# Altium schematic sheet Prosjektoppgave.SchDoc
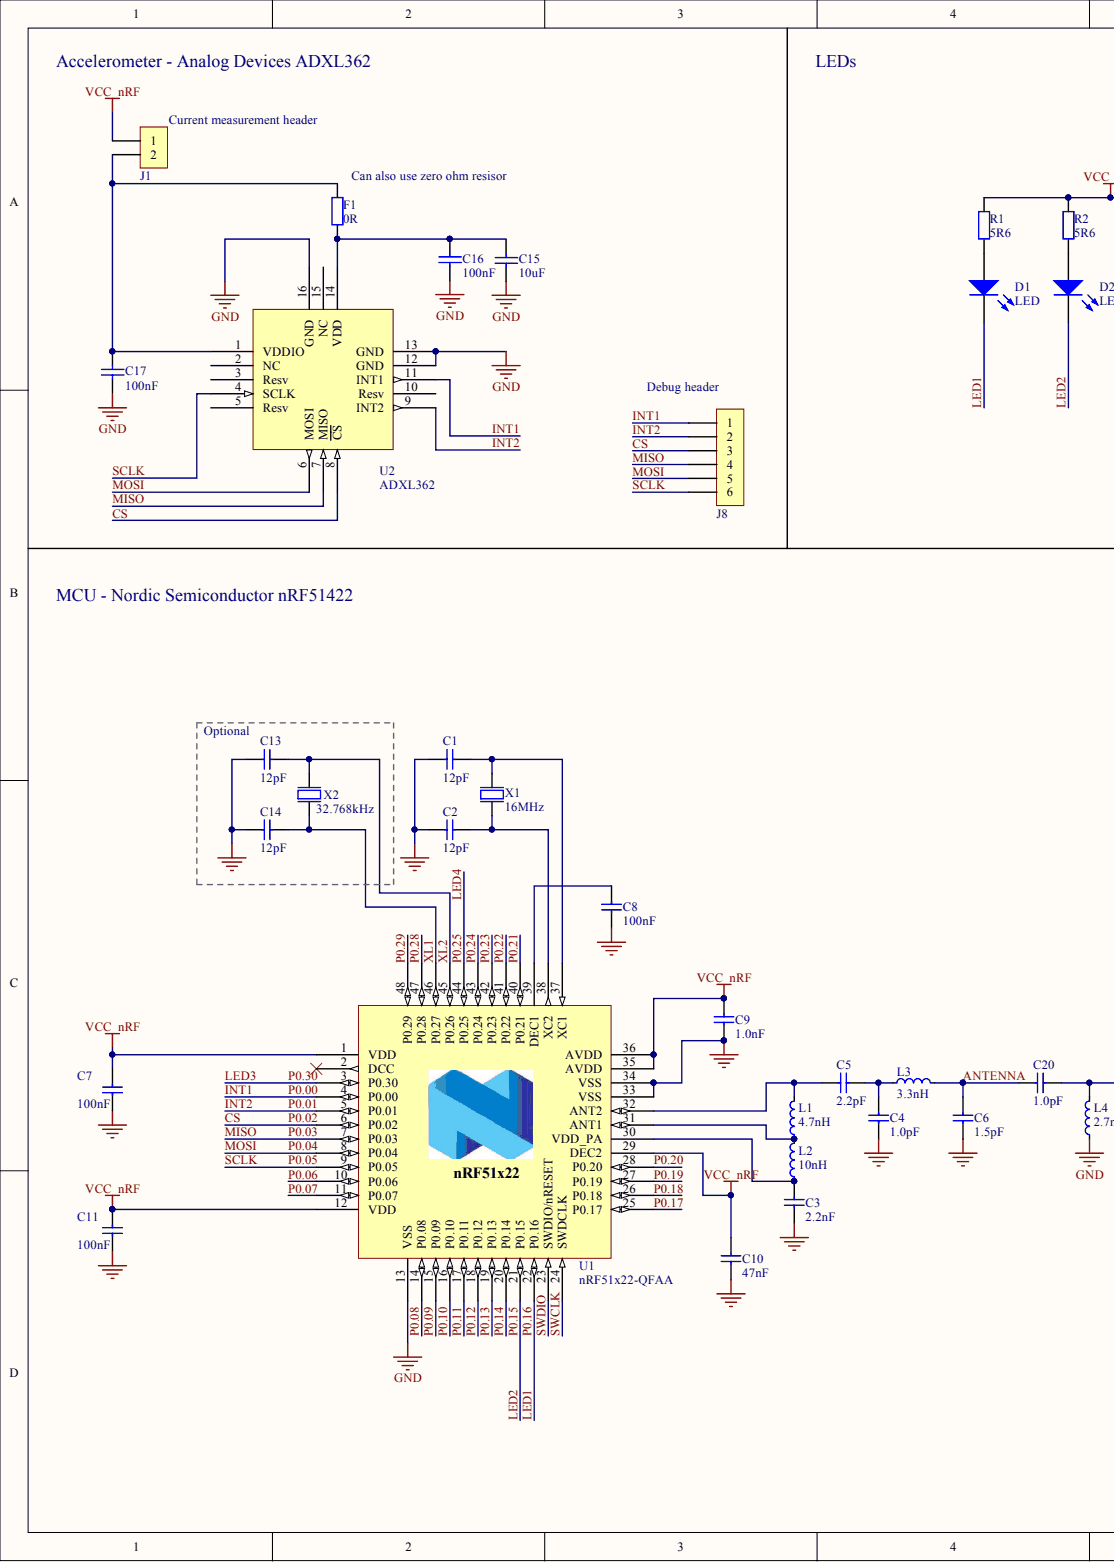
[diagram p0: Prosjektoppgave.SchDoc, left]
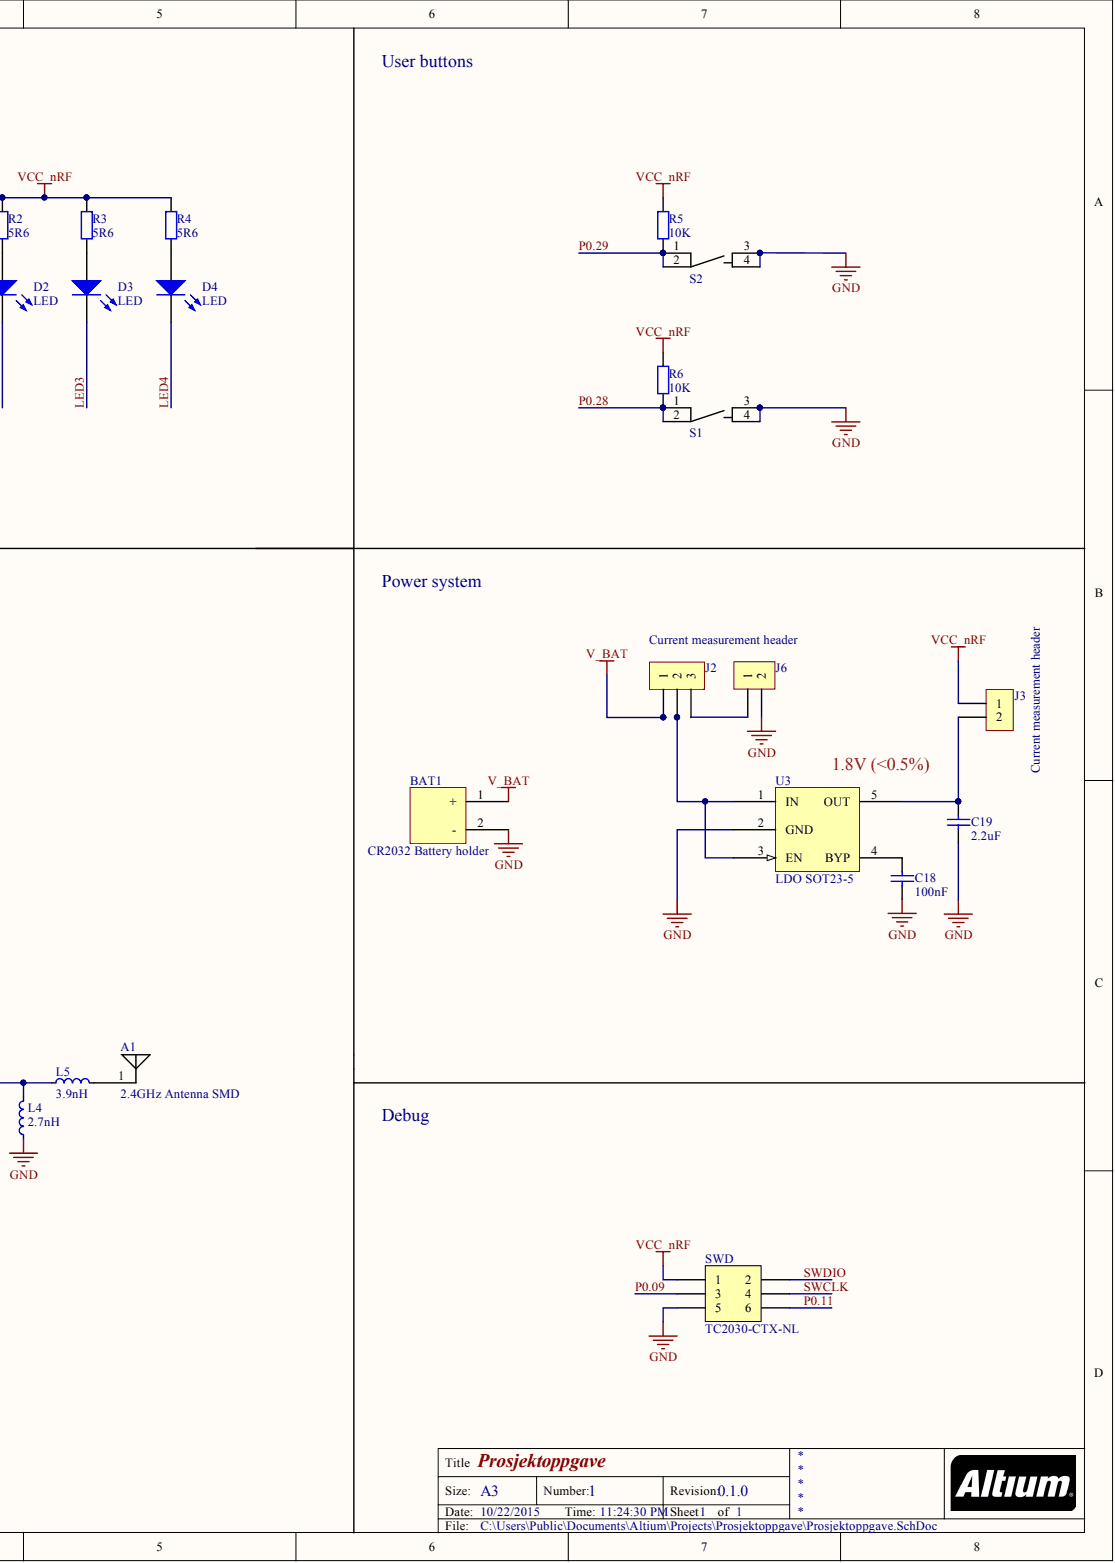
[diagram p1: Prosjektoppgave.SchDoc, right]

## Parts
A1  2.4GHz Antenna SMD  [JOHANSON_2.4GHZ_ANTENNA]
BAT1  CR2032 Battery holder  [BU2032SM]
C1  12pF  [CAPC1005X04L]
C2  12pF  [CAPC1005X04L]
C3  2.2nF  [CAPC1005X04L]
C4  1.0pF  [CAPC1005X04L]
C5  2.2pF  [CAPC1005X04L]
C6  1.5pF  [CAPC1005X04L]
C7  100nF  [CAPC1005X04L]
C8  100nF  [CAPC1005X04L]
C9  1.0nF  [CAPC1005X04L]
C10  47nF  [CAPC1005X04L]
C11  100nF  [CAPC1005X04L]
C13  12pF  [CAPC1005X04L]
C14  12pF  [CAPC1005X04L]
C15  value 10uF  [0402CAP]  (hidden comment: CAP 10uF)
C16  value 100nF  [0402CAP]  (hidden comment: CAP 100nF)
C17  value 100nF  [0402CAP]  (hidden comment: CAP 100nF)
C18  value 100nF  [0402CAP]  (hidden comment: CAP 100nF)
C19  value 2.2uF  [0402CAP]  (hidden comment: CAP 2.2uF)
C20  1.0pF  [CAPC1005X04L]
D1  LED  [0603 - LED]
D2  LED  [0603 - LED]
D3  LED  [0603 - LED]
D4  LED  [0603 - LED]
F1  value 0R  [0402]  (hidden comment: RES0)
J1  1x2 Pin-header  [HDR1X2]  (value not drawn)
J2  1x3 Pin-header  [HDR1X3 - header]  (value not drawn)
J3  1x2 Pin-header  [HDR1X2]  (value not drawn)
J6  1x2 Pin-header  [HDR1X2]  (value not drawn)
J8  1x6 Pin-header  [HDR1X6]  (value not drawn)
L1  4.7nH  [INDC1005X04L]
L2  10nH  [INDC1005X04L]
L3  3.3nH  [INDC1005X04L]
L4  2.7nH  [INDC1005X04L]
L5  3.9nH  [INDC1005X04L]
R1  value 5R6  [0402]  (hidden comment: RES)
R2  value 5R6  [0402]  (hidden comment: RES)
R3  value 5R6  [0402]  (hidden comment: RES)
R4  value 5R6  [0402]  (hidden comment: RES)
R5  value 10K  [0402]  (hidden comment: RES10)
R6  value 10K  [0402]  (hidden comment: RES10)
S1  Tactile switch Hole  [Tactile HOLE Switch]  (value not drawn)
S2  Tactile switch Hole  [Tactile HOLE Switch]  (value not drawn)
SWD  TC2030-CTX-NL  [TC2030-IDC-NLFP]  (no BOM)
U1  nRF51x22-QFAA  [QFN40P600X600X90-48N]
U2  ADXL362  [ADXL362]
U3  LDO SOT23-5  [SOT23-5]
X1  16MHz  [BT-XTAL_2520]
X2  32.768kHz  [XTAL_3215]

## Nets
GND: BAT1.2 C1.2 C2.2 C3.2 C4.2 C6.2 C7.2 C8.2 C9.2 C10.2 C11.2 C13.1 C14.1 C15.1 C16.1 C18.1 C19.1 J6.2 L4.1 S1.3 S1.4 S2.3 S2.4 SWD.5 U1.13(VSS) U1.33(VSS) U1.34(VSS) U1.49 U2.12(GND) U2.13(GND) U2.16(GND) U3.2(GND) X1.2 X1.4
V_BAT: BAT1.1 J2.1
VCC_NRF: C7.1 C9.1 C10.1 C11.1 J1.1 J3.1 R1.2 R2.2 R3.2 R4.2 R5.2 R6.2 SWD.1 U1.1(VDD) U1.12(VDD) U1.29(DEC2) U1.35(AVDD) U1.36(AVDD)
ANTENNA: C6.1 C20.1 L3.2
CS: J8.3 U1.6(P0.02) U2.8(CS)
INT1: J8.1 U1.4(P0.00) U2.11(INT1)
INT2: J8.2 U1.5(P0.01) U2.9(INT2)
LED1: D1.2 U1.22(P0.16)
LED2: D2.2 U1.21(P0.15)
LED3: D3.2 U1.3(P0.30)
LED4: D4.2 U1.44(P0.25)
MISO: J8.4 U1.7(P0.03) U2.7(MISO)
MOSI: J8.5 U1.8(P0.04) U2.6(MOSI)
P0.05: J8.6 U1.9(P0.05) U2.4(SCLK)
P0.06: U1.10(P0.06)
P0.07: U1.11(P0.07)
P0.08: U1.14(P0.08)
P0.09: SWD.3 U1.15(P0.09)
P0.10: U1.16(P0.10)
P0.11: SWD.6 U1.17(P0.11)
P0.12: U1.18(P0.12)
P0.13: U1.19(P0.13)
P0.14: U1.20(P0.14)
P0.17: U1.25(P0.17)
P0.18: U1.26(P0.18)
P0.19: U1.27(P0.19)
P0.20: U1.28(P0.20)
P0.21: U1.40(P0.21)
P0.22: U1.41(P0.22)
P0.23: U1.42(P0.23)
P0.24: U1.43(P0.24)
P0.28: R6.1 S1.1 S1.2 U1.47(P0.28)
P0.29: R5.1 S2.1 S2.2 U1.48(P0.29)
SWCLK: SWD.4 U1.24(SWDCLK)
SWDIO: SWD.2 U1.23(SWDIO/nRESET)
XL1: C14.2 U1.46(P0.27) X2.2
XL2: C13.2 U1.45(P0.26) X2.1
NetA1_1: A1.1 L5.2
NetC1_1: C1.1 U1.37(XC1) X1.1
NetC2_1: C2.1 U1.38(XC2) X1.3
NetC3_1: C3.1 L2.1 U1.30(VDD_PA)
NetC4_1: C4.1 C5.2 L3.1
NetC5_1: C5.1 L1.2 U1.32(ANT2)
NetC8_1: C8.1 U1.39(DEC1)
NetC15_2: C15.2 C16.2 F1.1 U2.14(VDD)
NetC17_2: C17.2 F1.2 J1.2 U2.1(VDDIO)
NetC18_2: C18.2 U3.4(BYP)
NetC19_2: C19.2 J3.2 U3.5(OUT)
NetC20_2: C20.2 L4.2 L5.1
NetD1_1: D1.1 R1.1
NetD2_1: D2.1 R2.1
NetD3_1: D3.1 R3.1
NetD4_1: D4.1 R4.1
NetJ2_2: J2.2 U3.1(IN) U3.3(EN)
NetJ2_3: J2.3 J6.1
NetL1_1: L1.1 L2.2 U1.31(ANT1)

Not connected: C17 1; U1 2(DCC); U2 2(NC) 3(Resv) 5(Resv) 10(Resv) 15(NC)

## Library data of the parts
A1 = ANTENNA SMD: Johanson SMD 2.4GHz antenna; Component Kind: Standard; Digi-Key: 712-1005-1-ND; Library Name: Prosjektoppgave.SchLib
BAT1 = CR2032 Battery holder: MPD Battery holder for CR2032 (BU2032SM); Component Kind: Standard; Digi-Key: BU2032SM-BT-GCT-ND; Library Name: Prosjektoppgave.SchLib
C1 C2 C13 C14 = C0402-12p: Capacitor, NP0, ±2%; Artikkelnr Noca: 20029159; Artikkelnr Simpro: N.A.; Database Table Name: Capacitors$; Note: 50V; Component Kind: Standard; Digi-Key: 712-1256-1-ND; Footprint Doc: 0402; LatestRevisionDate: 2011-05-11; Library Name: Nordic Passive DB.DbLib; Part Number: C0402-12p; Pin Count: 2; Published: 2009-05-08; Value: 12pF; Publisher: Nordic Semiconductor
C3 = C0402-2n2: Capacitor, X7R, ±10%; Artikkelnr Noca: 20039221; Artikkelnr Simpro: N.A.; Database Table Name: Capacitors$; Note: 50V; Component Kind: Standard; Digi-Key: 490-6359-1-ND; Footprint Doc: 0402; LatestRevisionDate: 2009-11-23; Library Name: Nordic Passive DB.DbLib; Part Number: C0402-2n2; Pin Count: 2; Published: 2009-05-08; Value: 2.2nF; Publisher: Nordic Semiconductor
C4 = C0402-1.0p: Capacitor, NP0, ±0.1pF; Artikkelnr Noca: 20029140; Artikkelnr Simpro: N.A.; Database Table Name: Capacitors$; Note: 50V; Component Kind: Standard; Digi-Key: 712-1266-1-ND; Footprint Doc: 0402; LatestRevisionDate: 2009-11-23; Library Name: Nordic Passive DB.DbLib; Part Number: C0402-1.0p; Pin Count: 2; Published: 2009-05-08; Value: 1.0pF; Publisher: Nordic Semiconductor
C5 = C0402-2.2p: Capacitor, NP0, ±0.1pF; Artikkelnr Noca: 20029145; Artikkelnr Simpro: N.A.; Database Table Name: Capacitors$; Note: 50V; Component Kind: Standard; Digi-Key: 712-1279-1-ND; Footprint Doc: 0402; LatestRevisionDate: 2009-05-08; Library Name: Nordic Passive DB.DbLib; Part Number: C0402-2.2p; Pin Count: 2; Published: 2009-05-08; Value: 2.2pF; Publisher: Nordic Semiconductor
C6 = C0402-1.5p: Capacitor, NP0, ±0.1pF; Artikkelnr Noca: 20029139; Artikkelnr Simpro: N.A.; Database Table Name: Capacitors$; Note: 50V; Component Kind: Standard; Digi-Key: 490-5891-1-ND; Footprint Doc: 0402; LatestRevisionDate: 2009-11-23; Library Name: Nordic Passive DB.DbLib; Part Number: C0402-1.5p; Pin Count: 2; Published: 2009-05-08; Value: 1.5pF; Publisher: Nordic Semiconductor
C7 C8 C11 = C0402-100n: Capacitor, X7R, ±10%; Artikkelnr Noca: 20039200; Artikkelnr Simpro: N.A.; Database Table Name: Capacitors$; Note: 16V; Component Kind: Standard; Digi-Key: 490-3261-1-ND; Footprint Doc: 0402; LatestRevisionDate: 2009-11-23; Library Name: Nordic Passive DB.DbLib; Part Number: C0402-100n; Pin Count: 2; Published: 2009-05-08; Value: 100nF; Publisher: Nordic Semiconductor
C9 = C0402-1n0: Capacitor, X7R, ±10%; Artikkelnr Noca: 20039223; Artikkelnr Simpro: N.A.; Database Table Name: Capacitors$; Note: 50V; Component Kind: Standard; Digi-Key: 490-1303-1-ND; Footprint Doc: 0402; LatestRevisionDate: 2009-11-23; Library Name: Nordic Passive DB.DbLib; Part Number: C0402-1n0; Pin Count: 2; Published: 2009-05-08; Value: 1.0nF; Publisher: Nordic Semiconductor
C10 = C0402-47n: Capacitor, X7R, ±10%; Artikkelnr Noca: 20039389; Artikkelnr Simpro: N.A.; Database Table Name: Capacitors$; Note: Chip Cap 10%; Component Kind: Standard; Digi-Key: 490-3254-1-ND; Footprint Doc: 0402; LatestRevisionDate: 2009-05-08; Library Name: Nordic Passive DB.DbLib; Part Number: C0402-47n; Pin Count: 2; Published: 2009-05-08; Value: 47nF; Publisher: Nordic Semiconductor
C15-C19 = CAP: Generic Capacitor; Component Kind: Standard; Library Name: cptr.SchLib
  C15: Digi-Key: 490-10692-1-ND
  C16-C18: Digi-Key: 490-3261-1-ND
  C19: Digi-Key: 490-10448-1-ND
C20 = CAP_0402: Capacitor, NP0, ±2%; Part Number: C0402-12p; Artikkelnr Noca: 20029159; Artikkelnr Simpro: N.A.; Note: 50V; Component Kind: Standard; Digi-Key: 712-1266-1-ND; Footprint Doc: 0402; LatestRevisionDate: 2011-05-11; Pin Count: 2; Published: 2009-05-08; Value: 1.0pF; Library Name: nrf51x22_qfax.IntLib; Publisher: Nordic Semiconductor
D1-D4 = LED Red: LED Red 0603; Manufacturer: Kingbright; MPN: APT1608SRCPRV; Component Kind: Standard; Digi-Key: 475-2709-2-ND; Digi-Key Price: 0.17; Library Name: cptr.SchLib
F1 R1-R6 = RES: Generic resistor; Component Kind: Standard; Library Name: cptr.SchLib
  F1: Digi-Key: 311-0.0JRCT-ND
  R1-R4: Digi-Key: 311-5.6JRCT-ND
  R5 R6: Digi-Key: 311-10KJRCT-ND
J1 J3 J6 = 1x2 Pin-header: Generic 1x2 2.54mm pitch generic pin header; Component Kind: Standard; Library Name: cptr.SchLib
J2 = 1x3 Pin-header: Generic 1x3 2.54mm pitch generic pin header; Component Kind: Standard; Library Name: cptr.SchLib
J8 = 1x6 Pin-header: Generic 1x6 2.54mm pitch generic pin header; Component Kind: Standard; Library Name: cptr.SchLib
L1 = L0402-4n7: High frequency chip inductor ±5%; Manufacturer: Murata; Manufacturer Part Number: LQP15MN4N7B02D; Artikkelnr Noca: 33029164; Artikkelnr Simpro: N.A.; Database Table Name: Inductors$; Component Kind: Standard; Datasheet: http://search.murata.co.jp/Ceramy/image/img/PDF/ENG/L0075S0084LQP15M.pdf; Digi-Key: 712-1417-1-ND; Footprint Doc: 0402; LatestRevisionDate: 2012-09-19; Library Name: Nordic Passive DB.DbLib; Note: High frequency chip inductor ±5%; Part Number: L0402-4n7; Pin Count: 2; Published: 2009-05-08; Supplier: Digi-Key; Supplier part number: 490-1132-1-ND; Value: 4.7nH; Publisher: Nordic Semiconductor
L2 = L0402-10n: High frequency chip inductor ±5%; Manufacturer: Murata; Manufacturer Part Number: LQP15MN10NG02D; Artikkelnr Noca: 33029425; Artikkelnr Simpro: N.A.; Database Table Name: Inductors$; Component Kind: Standard; Datasheet: http://search.murata.co.jp/Ceramy/image/img/PDF/ENG/L0075S0084LQP15M.pdf; Digi-Key: 712-1407-1-ND; Footprint Doc: 0402; LatestRevisionDate: 2012-09-19; Library Name: Nordic Passive DB.DbLib; Note: High frequency chip inductor ±5%; Part Number: L0402-10n; Pin Count: 2; Published: 2010-07-12; Supplier: Mouser; Supplier part number: 81-LQP15MN10NG02D; Value: 10nH; Publisher: Nordic Semiconductor
L3 = L0402-3n3: High frequency chip inductor ±5%; Manufacturer: Murata; Manufacturer Part Number: LQP15MN3N3B02D; Artikkelnr Noca: 33029369; Artikkelnr Simpro: N.A.; Database Table Name: Inductors$; Component Kind: Standard; Datasheet: http://search.murata.co.jp/Ceramy/image/img/PDF/ENG/L0075S0084LQP15M.pdf; Digi-Key: 712-1416-1-ND; Footprint Doc: 0402; LatestRevisionDate: 2012-09-19; Library Name: Nordic Passive DB.DbLib; Note: High frequency chip inductor ±5%; Part Number: L0402-3n3; Pin Count: 2; Published: 2009-05-08; Supplier: Digi-Key; Supplier part number: 490-1130-1-ND; Value: 3.3nH; Publisher: Nordic Semiconductor
L4 L5 = IND_0402: High frequency chip inductor ±10%; 2nd source part number: 658-1328; Manufacturer: Taiyo Yuden; Manufacturer Part Number: HK100515NJ-T; Part Number: L0402-15n; Artikkelnr Noca: 33029323; Artikkelnr Simpro: N.A.; 2nd source Supplier: Farnell; Component Kind: Standard; Datasheet: http://www.yuden.co.jp/productdata/catalog/en/mlci04_e.pdf; Footprint Doc: 0402; LatestRevisionDate: 2012-09-19; Note: High frequency chip inductor ±10%; Pin Count: 2; Published: 2010-07-12; Supplier: Digi-Key; Supplier part number: 587-1521-1-ND; Library Name: nrf51x22_qfax.IntLib; Publisher: Nordic Semiconductor
  L4: Digi-Key: 712-1415-1-ND; Value: 2.7nH
  L5: Digi-Key: 712-1459-1-ND; Value: 3.9nH
SWD = CONN-2x3_No_BOM: CBL PLUG-OF-NAILS 6-PIN; Manufacturer: Tag-Connect LLC; Artikkelnr Noca: N.A.; Datasheet: http://www.tag-connect.com/Materials/TC2030-IDC-NL.pdf; LatestRevisionDate: 2013-08-08; Library Name: pca20006.IntLib; Manufacturer Part Number: TC2030-CTX-NL; Part Number: TC2030-CTX-NL; Pin Count: 6; Published: 2013-08-08; Supplier: Tag-Connect; Supplier part number: TC2030-CTX-NL; Value: TC2030-CTX-NL; Publisher: Nordic Semiconductor
U1 = nRF51x22-QFAA: Multi-protocol Bluetooth Low Energy, ANT and 2.4GHz proprietary system-on-chip; Manufacturer: Nordic Semiconductor; Artikkelnr Noca: N.A.; Database Table Name: nRF Devices$; Note: nRF51422 - QFN48 6x6 0.4mm pitch; Artikkelnr Simpro: N.A.; Component Kind: Standard; Digi-Key: 1490-1030-2-ND; Footprint Doc: QFN-48; LatestRevisionDate: 2014-09-24; Library Name: Nordic Active DB.DbLib; Manufacturer Part Number: nRF51x22-QFAA; Part Number: nRF51x22-QFAA; Pin Count: 48; Published: 2014-09-24; Supplier: Nordic Semiconductor; Value: nRF51x22-QFAA; Publisher: Nordic Semiconductor
U2 = ADXL362: Ultra low power 3-axis accelerometer; Component Kind: Standard; Digi-Key: ADXL362BCCZ-R2TR-ND; Library Name: Prosjektoppgave.SchLib
U3 = LDO SOT23-5: LDO Voltage regulator; Component Kind: Standard; Digi-Key: 296-17778-1-ND; Library Name: Prosjektoppgave.SchLib
X1 = NX2520SA-16MHZ-STD-CSW-5: XTAL SMD 2520, 16MHz, 8pF, ±40ppm; Manufacturer: NDK; Artikkelnr Noca: 22019192; Artikkelnr Simpro: N.A.; Database Table Name: Crystal_Osc$; Note: XTAL SMD 16.000MHz; Component Kind: Standard; Datasheet: http://www.ndk.com/images/products/catalog/c_NX2520SA-STD-CSW-5_e.pdf; Digi-Key: 644-1169-1-ND; Footprint Doc: BT-XTAL_2520; LatestRevisionDate: 2012-06-15; Library Name: Nordic Discrete DB.DbLib; Manufacturer Part Number: NX2520SA-16MHZ-STD-CSW-5; Part Number: NX2520SA-16MHZ-STD-CSW-5; Pin Count: 4; Published: 2012-06-15; Supplier: Digi-Key; Supplier part number: 644-1169-1-ND; Value: 16MHz; Publisher: Nordic Semiconductor
X2 = XTAL_FC-135 32.768k: XTAL SMD 3215, 32.768kHz, 9pF, ±20ppm; 2nd source part number: 127-8037; Manufacturer: Epson Toyocom; Manufacturer Part Number: FC-135 32.768KHZ ±20PPM,9.0PF; Artikkelnr Noca: 22019091; Artikkelnr Simpro: N.A.; Database Table Name: Crystal_Osc$; Note: XTAL SMD 32.768kHz, 3215; 2nd source Supplier: Farnell; Component Kind: Standard; Datasheet: http://www.farnell.com/datasheets/57480.pdf; Digi-Key: FC-135 32.7680KA-AC-ND; Footprint Doc: XTAL_3215; LatestRevisionDate: 2014-03-27; Library Name: Nordic Discrete DB.DbLib; Part Number: XTAL_FC-135 32.768k; Pin Count: 2; Published: 2010-10-18; Supplier: Digi-Key; Supplier part number: FC-135 32.7680KA-AC-ND; Value: 32.768kHz; Publisher: Nordic Semiconductor
S1 S2 = Tactile switch Hole: Component Kind: Standard; Description: Tactile switch Hole; Digi-Key: P8009S-ND; Library Name: cptr.SchLib
